# Altium schematic sheet LED_DRV_IPM.SchDoc
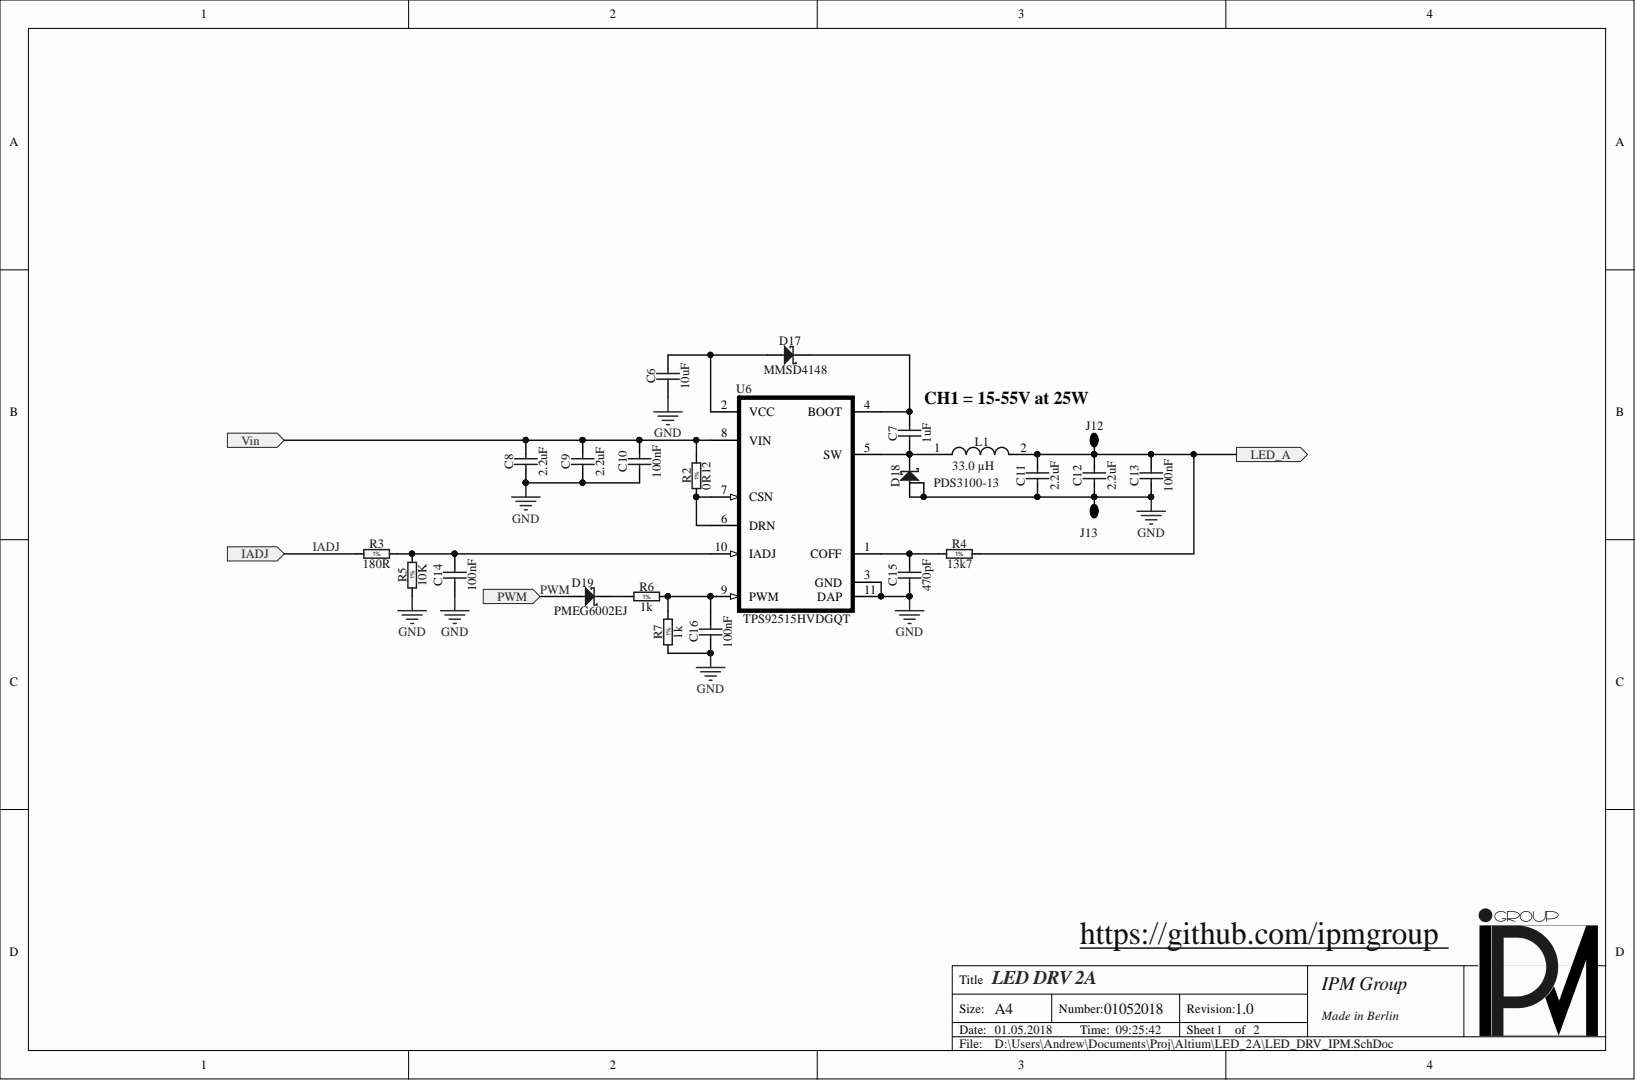
[diagram p0: LED_DRV_IPM.SchDoc]

## Parts
C6  10uF  [CAPC1608X87N]
C7  1uF  [CAPC1608X87N]
C8  2.2uF  [CAPC3216X180N]
C9  2.2uF  [CAPC3216X180N]
C10  100nF  [CAPC2012X135N]
C11  2.2uF  [CAPC3216X180N]
C12  2.2uF  [CAPC3216X180N]
C13  100nF  [CAPC2012X135N]
C14  100nF  [CAPC1608X87N]
C15  470pF  [CAPC1608X87N]
C16  100nF  [CAPC1608X87N]
D17  MMSD4148  [SOD-123]
D18  PDS3100-13  [POWERDI5]
D19  PMEG6002EJ  [SOD323F]
J12  2 way net tie junction  [J_pin_1_5x1_5RS]  (value not drawn)
J13  2 way net tie junction  [J_pin_1_5x1_5RS]  (value not drawn)
L1  33.0 µH  [WE-PD 1050]
R2  0R12  [RESC3216X06N]
R3  180R  [RESC1608X55N]
R4  13k7  [RESC1608X55N]
R5  10K  [RESC1608X55N]
R6  1k  [RESC1608X55N]
R7  1k  [RESC1608X55N]
U6  TPS92515HVDGQT  [DGQ0010E_N]

## Ports
IADJ, LED_A, PWM, Vin

## Nets
GND: C6.1 C8.1 C9.1 C10.1 C11.1 C12.1 C13.1 C14.1 C15.1 C16.1 D18.1 D18.2 J13.1 R5.1 R7.1 U6.3(GND) U6.11(DAP)
IADJ: R3.1 ; port IADJ
LED_A: C11.2 C12.2 C13.2 J12.1 L1.2 R4.2 ; port LED_A
PWM: D19.2 ; port PWM
Vin: C8.2 C9.2 C10.2 R2.2 U6.8(VIN) ; port Vin
NetC6_2: C6.2 D17.2 U6.2(VCC)
NetC7_1: C7.1 D18.3 L1.1 U6.5(SW)
NetC7_2: C7.2 D17.1 U6.4(BOOT)
NetC14_2: C14.2 R3.2 R5.2 U6.10(IADJ)
NetC15_2: C15.2 R4.1 U6.1(COFF)
NetC16_2: C16.2 R6.2 R7.2 U6.9(PWM)
NetD19_1: D19.1 R6.1
NetR2_1: R2.1 U6.6(DRN) U6.7(CSN)

## Library data of the parts
C6 = (no symbol name): CAP, CERM, 10 µF, 6.3 V,+/- 20%, X5R, 0603; Manufacturer: KEMET; Manufacturer Part Number: C0603C106M9PACTU; Voltage: 6.3V; Case: 0603; Component Kind: Standard; Library Name: Capacitor.SchLib; Manufacturer1 ComponentHeight: 0.95mm; Mounted: Yes; Part Description: CAP, CERM, 10 µF, 6.3 V,+/- 20%, X5R, 0603; Part Number: C0603C106M9PACTU; Pin Count: 2; SMD: Yes; Supplier 1: Farnell; Supplier 2: RSComponents; Supplier 3: Digi-Key; Supplier Part Number 1: 1288201; TC: X5R; Tolerance: ±20%; Value: 10uF; Author: CERN DEM JLC
C7 = C0603_1uF 35V: CAP, CERM, 1 µF, 35 V, ± 10%, X7R; Manufacturer: TDK; Manufacturer Part Number: C1608X7R1V105K080AC; Voltage: 35V; Case: 0603; Component Kind: Standard; Library Name: Capacitor.SchLib; Manufacturer1 ComponentHeight: 0.95mm; Mounted: Yes; Part Description: CAP, CERM, 1 µF, 35 V, ± 10%, X7R; Part Number: C1608X7R1V105K080AC; Pin Count: 2; SMD: Yes; Supplier 1: Farnell; Supplier 2: RSComponents; Supplier 3: Digi-Key; Supplier Part Number 1: 2346909; TC: X7R; Tolerance: ±10%; Value: 1uF; Author: CERN DEM JLC
C8 C9 C11 C12 = (no symbol name): CAP, CERM, 1206, 2.2 µF, 100 V, ± 10%, X7S; Manufacturer: TDK; Manufacturer1 Part Number: CGA5L3X7S2A225K160AB; Manufacturer Part Number: C3216X7S2A225K160AB; TC: X7R; Tolerance: ±20%; Voltage: 100V; Case: 1206; Component Kind: Standard; Library Name: Capacitor.SchLib; Manufacturer1 ComponentHeight: 1.6mm; Manufacturer1 Example: TDK; Mounted: Yes; Part Description: CAP, CERM, 1206, 2.2 µF, 100 V, ± 10%, X7S; Part Number: C3216X7S2A225K160AB; Pin Count: 2; Price: 0,767 €; SMD: Yes; Supplier 1: Farnell; Supplier 2: RSComponents; Supplier 3: Digi-Key; Supplier Part Number 1: 2112717; Supplier Part Number 2: 903-8837; Supplier Part Number 3: 445-6994-1-ND; Value: 2.2uF; Author: CERN DEM JLC
C10 C13 = C0805_100nF 100V: CAP, CERM, 0805 , 0.1 µF, 100 V, ± 5%, X7R; Manufacturer: AVX; Manufacturer Part Number: 08051C104JAT2A; Voltage: 100V; Case: 0805; Component Kind: Standard; Library Name: Capacitor.SchLib; Manufacturer1 ComponentHeight: 0.87mm; Mounted: Yes; Part Description: CAP, CERM, 0805 , 0.1 µF, 100 V, ± 5%, X7R; Pin Count: 2; SMD: Yes; Supplier 1: Farnell; Supplier 2: RSComponents; Supplier 3: Digi-Key; Supplier Part Number 1: 2332715; Supplier Part Number 3: 478-5780-1-ND; TC: X7R; Tolerance: ±5%; Value: 100nF; Author: CERN DEM JLC
C14 C16 = C0603_100nF 50V: CAP, CERM, 0603, 0.1 µF, 50 V, ± 10%, X7R; Manufacturer: KEMET; Manufacturer Part Number: C0603X104K5RACTU; TC: Y5V; Voltage: 50V; Case: 0603; Component Kind: Standard; Library Name: Capacitor.SchLib; Manufacturer1 ComponentHeight: 0.87mm; Mounted: Yes; Part Description: CAP, CERM, 0603, 0.1 µF, 50 V, ± 10%, X7R; Part Number: C0603X104K5RACTU; Pin Count: 2; SMD: Yes; Supplier 1: Farnell; Supplier 2: RSComponents; Supplier 3: Digi-Key; Supplier Part Number 1: 2629474; Tolerance: 10%; Value: 100nF; Author: CERN DEM JLC
C15 = C0603_470pF 50V: CAP, CERM, 0603, 470 pF, 50 V, ± 5%, C0G / NP0; Manufacturer: MULTICOMP; Manufacturer Part Number: MC0603N471J500CT; TC: X7R; Voltage: 50V; Case: 0603; Component Kind: Standard; Library Name: Capacitor.SchLib; Manufacturer1 ComponentHeight: 0.87mm; Mounted: Yes; Part Description: CAP, CERM, 0603, 470 pF, 50 V, ± 5%, C0G / NP0; Part Number: MC0603N471J500CT; Pin Count: 2; SMD: Yes; Supplier 1: Farnell; Supplier 2: RSComponents; Supplier 3: Digi-Key; Supplier Part Number 1: 1759080; Tolerance: ±5%; Value: 470pF; Author: CERN DEM JLC
D17 = (no symbol name): Small Signal Diode, Single, 100 V, 200 mA, 1 V, 4 ns, 2 A; Manufacturer: ON Semiconductor; Part Description: Power Schottky rectifier; Power: 200mA; Voltage: 100V; Case: SOD-123; Component Kind: Standard; Library Name: My_PCB.SchLib; Manufacturer1 ComponentHeight: 1.18mm; Manufacturer Part Number: MMSD4148; Mounted: Yes; PackageDescription: Small Signal Diode, Single, 100 V, 200 mA, 1 V, 4 ns, 2 A; Part Number: MMSD4148; Pin Count: 2; Price: 0,182 €; SMD: Yes; Supplier 1: Farnell; Supplier 2: RSComponents; Supplier 3: Digi-Key; Supplier Part Number 1: 1467565; Supplier Part Number 3: MMSD4148T3GOSCT-ND; Author: CERN DEM JLC
D18 = (no symbol name): Schottky Rectifier, 100 V, 3 A, Single, PowerDI 5, 3 Pins, 760 mV; Manufacturer: DiodesZetex; Part Description: Power Schottky rectifier; Power: 3A; Voltage: 100V; Case: PowerDI 5; Component Kind: Standard; Library Name: My_PCB.SchLib; Manufacturer1 ComponentHeight: 1.15mm; Manufacturer Part Number: PDS3100-13; Mounted: Yes; PackageDescription: Schottky Rectifier, 100 V, 3 A, Single, PowerDI 5, 3 Pins, 760 mV; Part Number: PDS3100-13; Pin Count: 3; Price: 0,0742 €; SMD: Yes; Supplier 1: Farnell; Supplier 2: RSComponents; Supplier 3: Digi-Key; Supplier Part Number 1: 2543573; Supplier Part Number 2: 751-4654; Supplier Part Number 3: PDS3100Q-13DICT-ND; Author: CERN DEM JLC
D19 = (no symbol name): Schottky Diode SOD-323F 60V 200mA; Manufacturer: MULTICOMP; Part Description: Power Schottky rectifier; Case: SOD-323F; Component Kind: Standard; Library Name: My_PCB.SchLib; Manufacturer1 ComponentHeight: 0.8mm; Manufacturer Part Number: PMEG6002EJ; Mounted: Yes; PackageDescription: SODFL, 2-Leads, Body 1,25x2,50mm, IPC Medium Density; Part Number: PMEG6002EJ; Pin Count: 2; Power: 200mA; Price: 0,481 €; SMD: Yes; Supplier 1: Farnell; Supplier 2: RSComponents; Supplier 3: Digi-Key; Supplier Part Number 1: 1972726; Supplier Part Number 2: 816-6883; Supplier Part Number 3: 1727-5214-1-ND; Voltage: 60V; Author: CERN DEM JLC
J12 J13 = 1way: 1 way net tie junction; Component Kind: Net Tie; Library Name: My_PCB.SchLib
L1 = WE-PD 1050, 7447714330: Power Inductor, 33 µH, 2.5 A, Shielded, 2.9 A, WE-PD Series, 10mm x 10mm x 5mm; Manufacturer: Würth Elektronik eiSos GmbH; Part Description: Power Inductor (SMD), 33 µH, 2.5 A, Shielded, 2.9 A, WE-PD Series, 10mm x 10mm x 5mm; Component Kind: Standard; Library Name: WE-PD.intlib; Manufacturer Part Number: 7447714330; Part Number: 7447714330; Pin Count: 2; Price: 3,21 €; SMD: Yes; Supplier 1: Farnell; Supplier 2: RSComponents; Supplier 3: Digi-Key; Supplier Part Number 1: 2082681; Supplier Part Number 2: 789-6974P; Supplier Part Number 3: 732-2993-1-ND; Value: 33.0 µH
R2 = R1206_0R12: RES, 0.12 ohm, 200 V, 1206, 500 mW; Manufacturer: WALSIN; Manufacturer1 Part Number: ERJ-8BSJR12V; Manufacturer Part Number: WW12PR120FTL; Power: 0.5W; TC: ±1000ppm/°C; Tolerance: ±1%; Case: 1206; Component Kind: Standard; Library Name: Resistor.SchLib; Manufacturer1 ComponentHeight: 0.6mm; Manufacturer1 Example: Panasonic Electronic Components; Mounted: Yes; Part Description: RES, 0.12 ohm, 200 V, 1206, 500 mW; Part Number: WW12PR120FTL; Pin Count: 2; Price: 0,804 €; SMD: Yes; Supplier 1: Farnell; Supplier 2: RSComponents; Supplier 3: Digi-Key; Supplier Part Number 1: 2503065; Supplier Part Number 3: P17687CT-ND; Value: 0R12; Author: CERN DEM JLC
R3 = (no symbol name): RES, 180 ohm, 75 V, 0603, 100 mW; Manufacturer: YAGEO; Manufacturer Part Number: RC0603FR-07180RL; Power: 0.1W; TC: ±100ppm/°C; Tolerance: ±1%; Case: 0603; Component Kind: Standard; Library Name: Resistor.SchLib; Manufacturer1 ComponentHeight: 0.55mm; Mounted: Yes; Part Description: RES, 180 ohm, 75 V, 0603, 100 mW; Part Number: RC0603FR-07180RL; Pin Count: 2; SMD: Yes; Supplier 1: Farnell; Supplier 2: RSComponents; Supplier 3: Digi-Key; Supplier Part Number 1: 9238395; Value: 180R; Author: CERN DEM JLC
R4 = R0603_13k7: RES, 13.7 kohm,  75 V, 0603, 100 mW; Manufacturer: WALSIN; Manufacturer1 Part Number: RC0603FR-0713K7L; Manufacturer Part Number: WR06X1372FTL; Power: 0.1W; TC: ±100ppm/°C; Tolerance: ±1%; Case: 0603; Component Kind: Standard; Library Name: Resistor.SchLib; Manufacturer1 ComponentHeight: 0.35mm; Manufacturer1 Example: Yageo; Mounted: Yes; Part Description: RES, 13.7 kohm,  75 V, 0603, 100 mW; Part Number: WR06X1372FTL; Pin Count: 2; Price: 0,0254 €; SMD: Yes; Supplier 1: Farnell; Supplier 2: RSComponents; Supplier 3: Digi-Key; Supplier Part Number 1: 2670631; Supplier Part Number 2: 708-8760; Supplier Part Number 3: 311-13.7KHRCT-ND; Value: 13k7; Author: CERN DEM JMW
R5 = (no symbol name): RES, 10 kohm,  75 V, 0603, 100 mW; Manufacturer: VISHAY; Manufacturer Part Number: CRCW060310K0FKEA; Power: 0.1W; TC: ±100ppm/°C; Tolerance: ±1%; Case: 0603; Component Kind: Standard; Library Name: Resistor.SchLib; Manufacturer1 ComponentHeight: 0.35mm; Mounted: Yes; Part Description: RES, 10 kohm,  75 V, 0603, 100 mW; Part Number: CRCW060310K0FKEA; Pin Count: 2; SMD: Yes; Supplier 1: Farnell; Supplier 2: RSComponents; Supplier 3: Digi-Key; Supplier Part Number 1: 1469748; Value: 10K; Author: CERN DEM JMW
R6 R7 = R0603_1K: RES, 1 kohm, 75 V, 0603, 100 mW; Manufacturer: PANASONIC; Manufacturer1 Part Number: CRCW06031K00FKEA; Manufacturer Part Number: ERJ3EKF1001V; Power: 0.1W; TC: ±100ppm/°C; Tolerance: ±1%; Case: 0603; Component Kind: Standard; Library Name: Resistor.SchLib; Manufacturer1 ComponentHeight: 0.35mm; Manufacturer1 Example: VISHAY; Mounted: Yes; Part Description: RES, 1 kohm,  75 V, 0603, 100 mW; Part Number: ERJ3EKF1001V; Pin Count: 2; SMD: Yes; Supplier 1: Farnell; Supplier 2: RSComponents; Supplier 3: Digi-Key; Supplier Part Number 1: 1469740; Supplier Part Number 2: 678-9875; Supplier Part Number 3: 541-3949-1-ND; Value: 1k; ValueDisplayed: 1k; Author: EVO_AB
U6 = TPS92515HVQDGQRQ1: LED Driver, Buck, 5.5V to 65V input, 1 Output, 2 MHz Switch. Frequency, 62V/2A out, HVSSOP-10; Manufacturer: Texas Instruments; Pitch: 0.5mm; Component Kind: Standard; Library Name: My_PCB.SchLib; Manufacturer1 ComponentHeight: 1.1mm; Manufacturer Part Number: TPS92515HVDGQT; Mounted: Yes; PackageDescription: VSSOP-10; Part Description: LED Driver, Buck, 5.5V to 65V input, 1 Output, 2 MHz Switch. Frequency, 62V/2A out, HVSSOP-10; Part Number: TPS92515HVDGQT; Pin Count: 10; Price: 2,09 €; SMD: Yes; Supplier 1: Farnell; Supplier 2: RSComponents; Supplier 3: Digi-Key; Supplier Part Number 1: 2643437; Supplier Part Number 2: 900-9826; Supplier Part Number 3: 296-44745-1-ND
